# Altium schematic sheet greenhouse_esp32_V0.1.schdoc
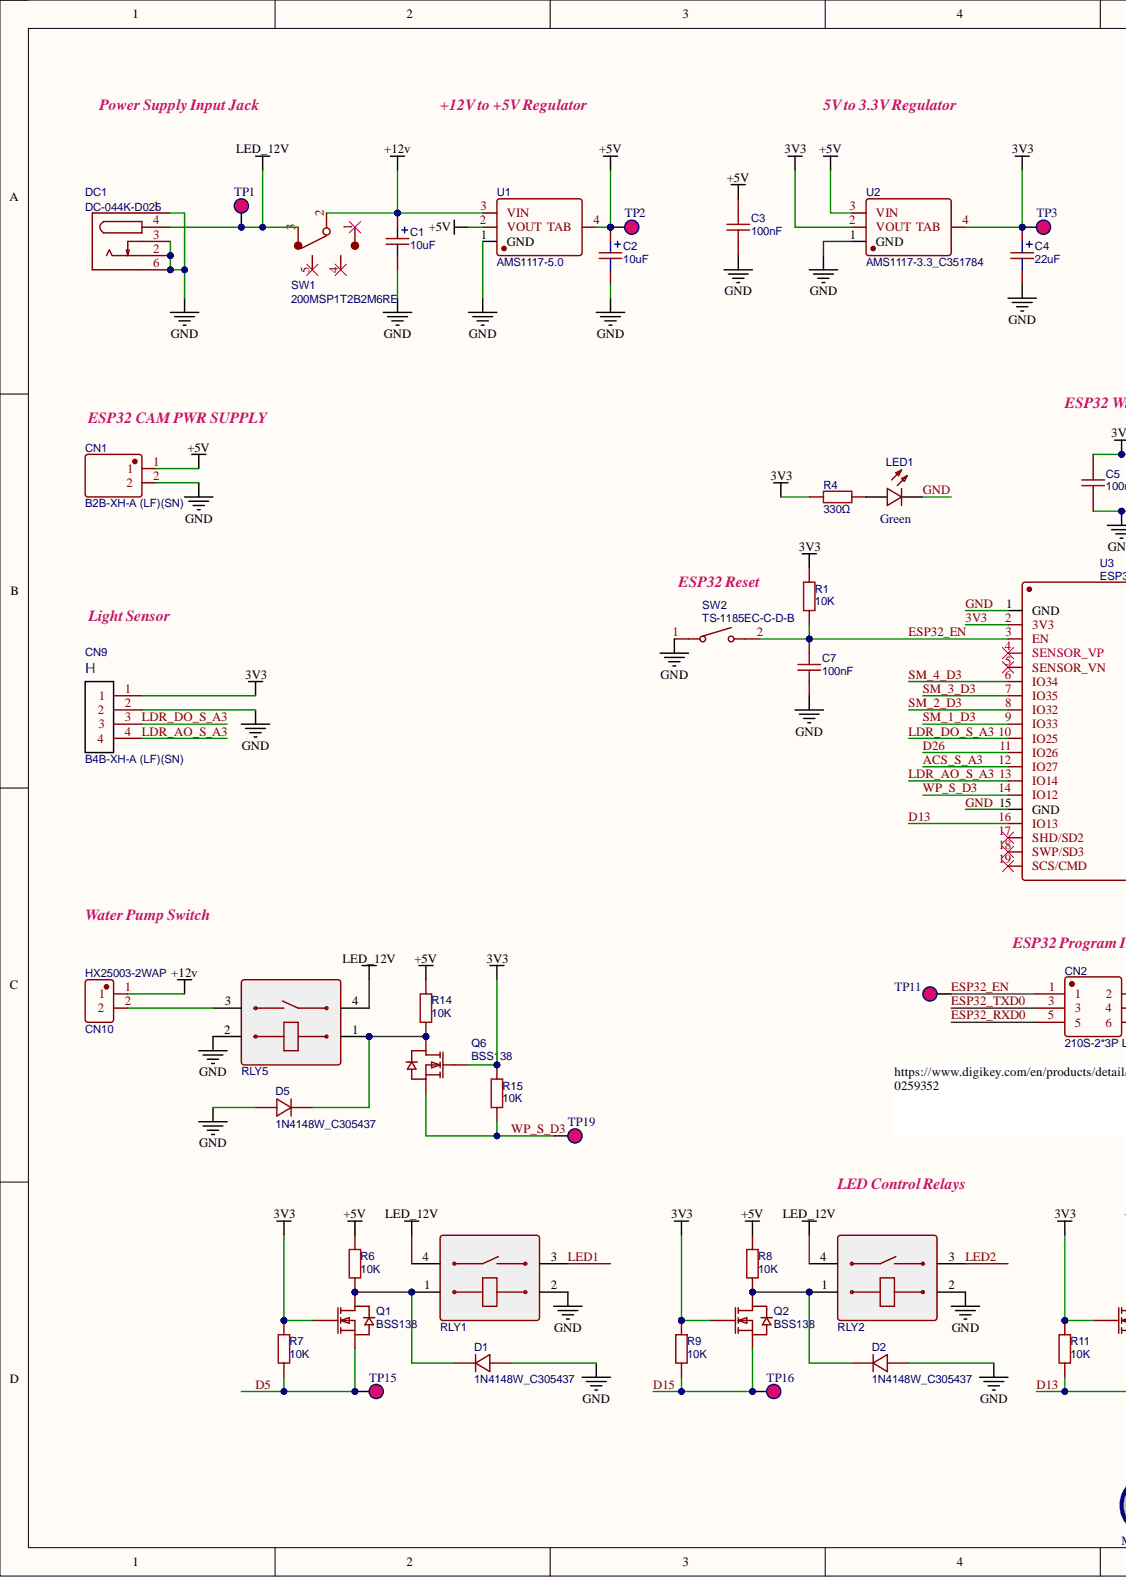
[diagram p0: greenhouse_esp32_V0.1.schdoc, left]
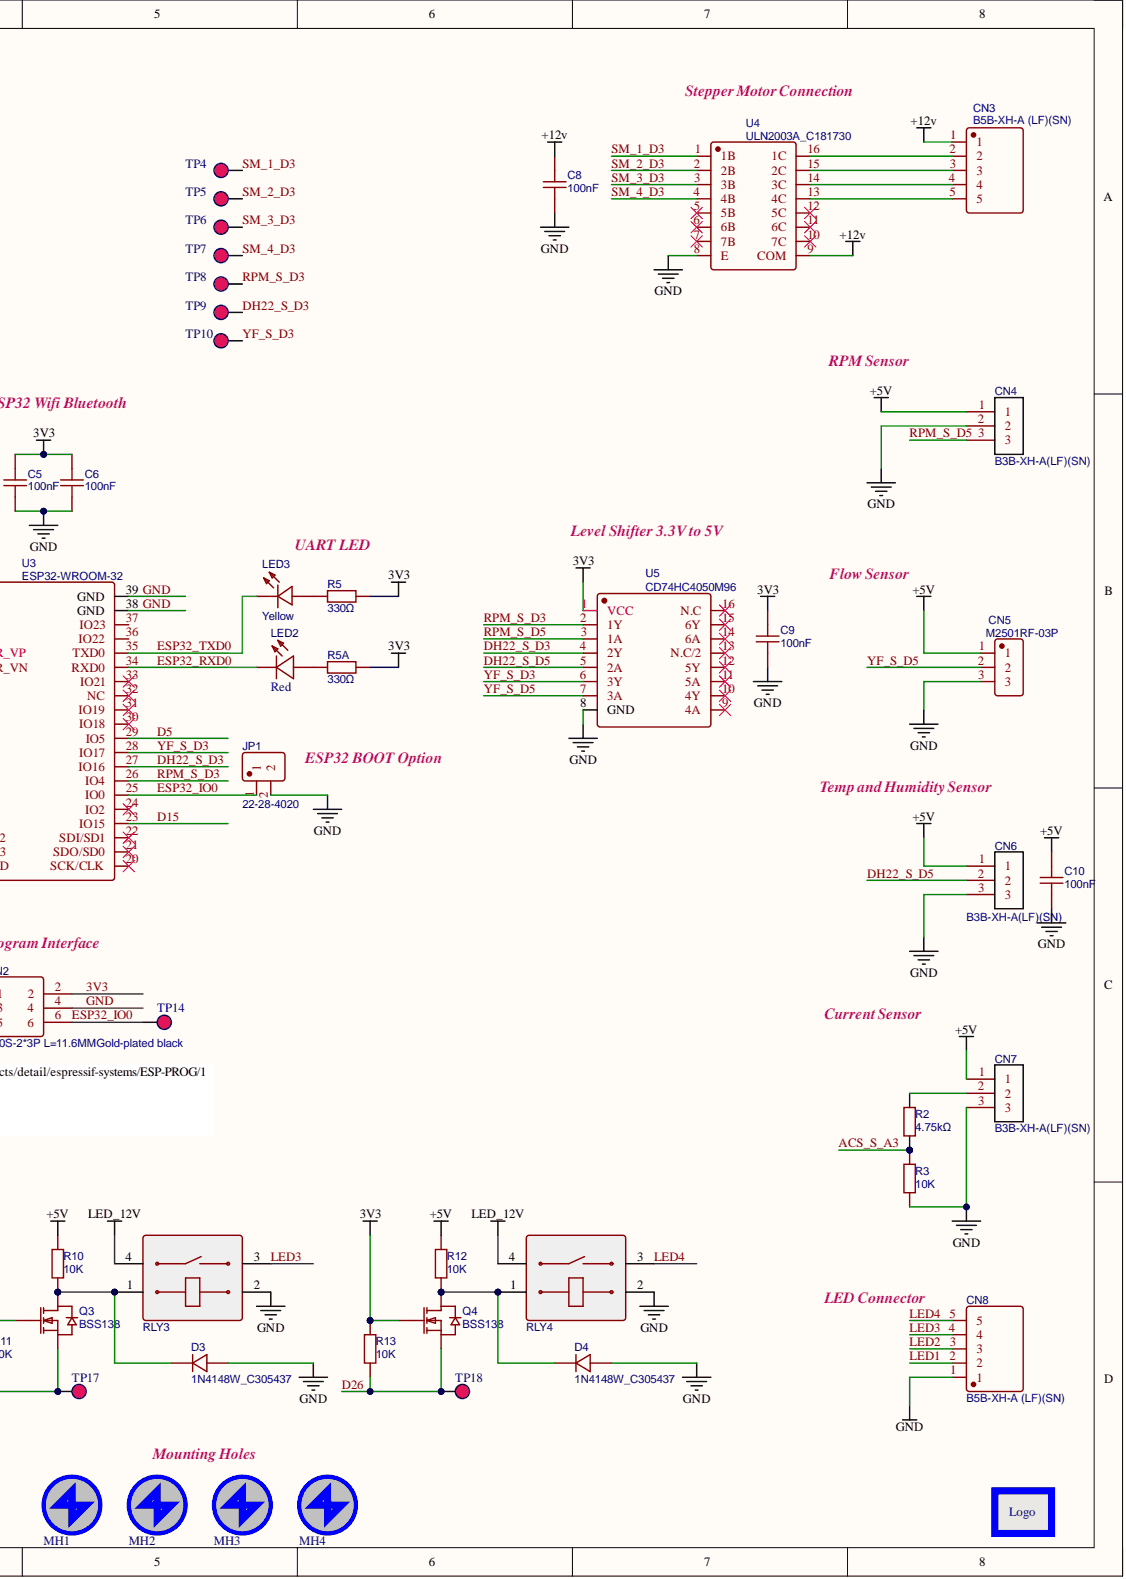
[diagram p1: greenhouse_esp32_V0.1.schdoc, right]

## Parts
C1  10uF  [CAPPM3528X210N]
C2  10uF  [CAPPM3528X210N]
C3  100nF  [C0805]
C4  22uF  [CAPPM3528X210N]
C5  100nF  [C0805]
C6  100nF  [C0805]
C7  100nF  [C0805]
C8  100nF  [C0805]
C9  100nF  [C0805]
C10  100nF  [C0805]
CN1  B2B-XH-A (LF)(SN)  [CONN-TH_B2B-XH-A-LF-SN]
CN2  210S-2*3P L=11.6MMGold-plated black  [HDR-TH_6P-P2.54-V-M-R2-C3-S2.54-1]
CN3  B5B-XH-A (LF)(SN)  [CONN-TH_B5B-XH-A-LF-SN]
CN4  B3B-XH-A(LF)(SN)  [CONN-TH_3P-P2.50_B3B-XH-A-LF-SN]
CN5  M2501RF-03P  [CONN-TH_M2501RF-03P]
CN6  B3B-XH-A(LF)(SN)  [CONN-TH_3P-P2.50_B3B-XH-A-LF-SN]
CN7  B3B-XH-A(LF)(SN)  [CONN-TH_3P-P2.50_B3B-XH-A-LF-SN]
CN8  B5B-XH-A (LF)(SN)  [CONN-TH_B5B-XH-A-LF-SN]
CN9  B4B-XH-A (LF)(SN)  [CONN-TH_B4B-XH-A-LF-SN]
CN10  HX25003-2WAP  [CONN-TH_2P-P2.50_HX25003-2WAP]
D1  1N4148W_C305437  [SOD-123F_L2.7-W1.7-LS3.7-RD-1]
D2  1N4148W_C305437  [SOD-123F_L2.7-W1.7-LS3.7-RD-1]
D3  1N4148W_C305437  [SOD-123F_L2.7-W1.7-LS3.7-RD-1]
D4  1N4148W_C305437  [SOD-123F_L2.7-W1.7-LS3.7-RD-1]
D5  1N4148W_C305437  [SOD-123F_L2.7-W1.7-LS3.7-RD-1]
DC1  DC-044K-D025  [DC-IN-TH_DC-044K-D025]
G1  Logo  [logo]  (no BOM)
JP1  22-28-4020  [HDR-TH_2P-P2.54-V-M-1]
LED1  value Green  [LED0603-RD]  (hidden comment: FC-D1608HYK-588J)
LED2  value Red  [LED0603-RD]  (hidden comment: FC-1608HRK-620H)
LED3  Yellow  [LED0603-RD]
MH1  Mounting Hole 3.4mm  [mounting_hole_3.4mm]  (value not drawn)  (no BOM)
MH2  Mounting Hole 3.4mm  [mounting_hole_3.4mm]  (value not drawn)  (no BOM)
MH3  Mounting Hole 3.4mm  [mounting_hole_3.4mm]  (value not drawn)  (no BOM)
MH4  Mounting Hole 3.4mm  [mounting_hole_3.4mm]  (value not drawn)  (no BOM)
Q1  BSS138  [SOT-23-3_L2.9-W1.3-P1.90-LS2.4-BR]
Q2  BSS138  [SOT-23-3_L2.9-W1.3-P1.90-LS2.4-BR]
Q3  BSS138  [SOT-23-3_L2.9-W1.3-P1.90-LS2.4-BR]
Q4  BSS138  [SOT-23-3_L2.9-W1.3-P1.90-LS2.4-BR]
Q6  BSS138  [SOT-23-3_L2.9-W1.3-P1.90-LS2.4-BR]
R1  10K  [RESC1608X55N]
R2  4.75kΩ  [RESC1608X55N]
R3  10K  [RESC1608X55N]
R4  330Ω  [R0603]
R5  330Ω  [R0603]
R5A  330Ω  [R0603]
R6  10K  [RESC1608X55N]
R7  10K  [RESC1608X55N]
R8  10K  [RESC1608X55N]
R9  10K  [RESC1608X55N]
R10  10K  [RESC1608X55N]
R11  10K  [RESC1608X55N]
R12  10K  [RESC1608X55N]
R13  10K  [RESC1608X55N]
R14  10K  [RESC1608X55N]
R15  10K  [RESC1608X55N]
RLY1  HF32F-G/005-HS  [RELAY-TH_HF32F-X-HXXXX-XXX]  (value not drawn)
RLY2  HF32F-G/005-HS  [RELAY-TH_HF32F-X-HXXXX-XXX]  (value not drawn)
RLY3  HF32F-G/005-HS  [RELAY-TH_HF32F-X-HXXXX-XXX]  (value not drawn)
RLY4  HF32F-G/005-HS  [RELAY-TH_HF32F-X-HXXXX-XXX]  (value not drawn)
RLY5  HF32F-G/005-HS  [RELAY-TH_HF32F-X-HXXXX-XXX]  (value not drawn)
SW1  200MSP1T2B2M6RE  [SW-TH_3P-P2.54_L9.1-W8.1]
SW2  TS-1185EC-C-D-B  [SW-SMD_4P-L3.7-W3.0-P1.50-LS4.7]
TP1  (no value)  [SMD_TP]  (no BOM)
TP2  (no value)  [SMD_TP]  (no BOM)
TP3  (no value)  [SMD_TP]  (no BOM)
TP4  (no value)  [SMD_TP]  (no BOM)
TP5  (no value)  [SMD_TP]  (no BOM)
TP6  (no value)  [SMD_TP]  (no BOM)
TP7  (no value)  [SMD_TP]  (no BOM)
TP8  (no value)  [SMD_TP]  (no BOM)
TP9  (no value)  [SMD_TP]  (no BOM)
TP10  (no value)  [SMD_TP]  (no BOM)
TP11  (no value)  [SMD_TP]  (no BOM)
TP14  (no value)  [SMD_TP]  (no BOM)
TP15  (no value)  [SMD_TP]  (no BOM)
TP16  (no value)  [SMD_TP]  (no BOM)
TP17  (no value)  [SMD_TP]  (no BOM)
TP18  (no value)  [SMD_TP]  (no BOM)
TP19  (no value)  [SMD_TP]  (no BOM)
U1  AMS1117-5.0  [SOT-223-3_L6.5-W3.4-P2.30-LS7.0-BR]
U2  AMS1117-3.3_C351784  [SOT-223-3_L6.5-W3.4-P2.30-LS7.0-BR]
U3  ESP32-WROOM-32  [WIFIM-SMD_ESP-32S]
U4  ULN2003A_C181730  [SOP-16_L10.0-W3.9-P1.27-LS6.0-BL]
U5  CD74HC4050M96  [SOIC-16_L9.9-W3.9-P1.27-LS6.0-BL]

## Nets
+5V: C2.1 C3.2 C10.2 CN1.1 CN4.1 CN5.1 CN6.1 CN7.1 R6.1 R8.1 R10.1 R12.1 R14.1 TP2.1 U1.2(VOUT) U1.4(TAB) U2.3(VIN)
+12v: C1.1 C8.2 CN3.1 CN10.1 SW1.2 U1.3(VIN) U4.9(COM)
LED_12V: DC1.4 RLY1.4 RLY2.4 RLY3.4 RLY4.4 RLY5.4 SW1.3 TP1.1
3V3: C4.1 C5.2 C6.2 C9.2 CN2.2 CN9.1 Q1.1 Q2.1 Q3.1 Q4.1 Q6.1 R1.2 R4.1 R5.1 R5A.1 R7.1 R9.1 R11.1 R13.1 R15.1 TP3.1 U2.2(VOUT) U2.4(TAB) U3.2(3V3) U5.1(VCC)
ACS_S_A3: R2.1 R3.2 U3.12(IO27)
D5: Q1.2 R7.2 TP15.1 U3.29(IO5)
D13: Q3.2 R11.2 TP17.1 U3.16(IO13)
D15: Q2.2 R9.2 TP16.1 U3.23(IO15)
D26: Q4.2 R13.2 TP18.1 U3.11(IO26)
DH22_S_D3: TP9.1 U3.27(IO16) U5.4(2Y)
DH22_S_D5: CN6.2 U5.5(2A)
ESP32_EN: C7.2 CN2.1 R1.1 SW2.2 TP11.1 U3.3(EN)
ESP32_IO0: CN2.6 JP1.1 TP14.1 U3.25(IO0)
ESP32_RXD0: CN2.5 LED2.1 U3.34(RXD0)
ESP32_TXD0: CN2.3 LED3.1 U3.35(TXD0)
GND: C1.2 C2.2 C3.1 C4.2 C5.1 C6.1 C7.1 C8.1 C9.1 C10.1 CN1.2 CN2.4 CN4.2 CN5.3 CN6.3 CN7.3 CN8.1 CN9.2 D1.2 D2.2 D3.2 D4.2 D5.2 DC1.1 DC1.2 DC1.3 DC1.6 JP1.2 LED1.1 R3.1 RLY1.2 RLY2.2 RLY3.2 RLY4.2 RLY5.2 SW2.1 U1.1(GND) U2.1(GND) U3.1(GND) U3.15(GND) U3.38(GND) U3.39(GND) U4.8(E) U5.8(GND)
LDR_AO_S_A3: CN9.4 U3.13(IO14)
LDR_DO_S_A3: CN9.3 U3.10(IO25)
LED1: CN8.2 RLY1.3
LED2: CN8.3 RLY2.3
LED3: CN8.4 RLY3.3
LED4: CN8.5 RLY4.3
RPM_S_D3: TP8.1 U3.26(IO4) U5.2(1Y)
RPM_S_D5: CN4.3 U5.3(1A)
SM_1_D3: TP4.1 U3.9(IO33) U4.1(1B)
SM_2_D3: TP5.1 U3.8(IO32) U4.2(2B)
SM_3_D3: TP6.1 U3.7(IO35) U4.3(3B)
SM_4_D3: TP7.1 U3.6(IO34) U4.4(4B)
WP_S_D3: Q6.2 R15.2 TP19.1 U3.14(IO12)
YF_S_D3: TP10.1 U3.28(IO17) U5.6(3Y)
YF_S_D5: CN5.2 U5.7(3A)
NetCN3_2: CN3.2 U4.16(1C)
NetCN3_3: CN3.3 U4.15(2C)
NetCN3_4: CN3.4 U4.14(3C)
NetCN3_5: CN3.5 U4.13(4C)
NetCN7_2: CN7.2 R2.2
NetCN10_2: CN10.2 RLY5.3
NetD1_1: D1.1 Q1.3 R6.2 RLY1.1
NetD2_1: D2.1 Q2.3 R8.2 RLY2.1
NetD3_1: D3.1 Q3.3 R10.2 RLY3.1
NetD4_1: D4.1 Q4.3 R12.2 RLY4.1
NetD5_1: D5.1 Q6.3 R14.2 RLY5.1
NetLED1_2: LED1.2 R4.2
NetLED2_2: LED2.2 R5A.2
NetLED3_2: LED3.2 R5.2

Not connected: SW1 1 4 5; U3 4(SENSOR_VP) 5(SENSOR_VN) 17(SHD/SD2) 18(SWP/SD3) 19(SCS/CMD) 20(SCK/CLK) 21(SDO/SD0) 22(SDI/SD1) 24(IO2) 30(IO18) 31(IO19) 32(NC) 33(IO21) 36(IO22) 37(IO23); U4 5(5B) 6(6B) 7(7B) 10(7C) 11(6C) 12(5C); U5 9(4A) 10(4Y) 11(5A) 12(5Y) 13(N.C/2) 14(6A) 15(6Y) 16(N.C)

## Library data of the parts
C1 C2 = T491B106K025AT: BOM_Manufacturer: KEMET; nameAlias: Capacitance; BOM_Manufacturer Part: T491B106K025AT; BOM_Supplier: LCSC; BOM_Supplier Part: C110053; Component Kind: Standard; spicePre: C; Value: 10uF; Library Name: GreenHouseEsp32_V0.1.SCHLIB
C3 C5-C10 = 100nF: BOM_Manufacturer: 火炬; BOM_Manufacturer Part: C0805B104K500NT; Contributor: LCSC; nameAlias: Capacitance; BOM_JLCPCB Part Class: Extended Part; BOM_Supplier: LCSC; BOM_Supplier Part: C476766; Component Kind: Standard; spicePre: C; spiceSymbolName: C0805B104K500NT; Library Name: GreenHouseEsp32_V0.1.SCHLIB
C4 = T491B226K006AT: 22uF 6.3V -55℃~+125℃ 2Ω@100kHz ±10% CASE-B_3528 Tantalum Capacitors ROHS; BOM_Manufacturer: KEMET; nameAlias: Capacitance; BOM_Manufacturer Part: T491B226K006AT; BOM_Supplier: LCSC; BOM_Supplier Part: C122276; Component Kind: Standard; spicePre: C; Value: 22uF; Library Name: GreenHouseEsp32_V0.1.SCHLIB
CN1 = B2B-XH-A (LF)(SN): BOM_Manufacturer: JST Sales America; Contributor: LCSC; BOM_JLCPCB Part Class: Extended Part; BOM_Manufacturer Part: B2B-XH-A(LF)(SN); BOM_Supplier: LCSC; BOM_Supplier Part: C158012; Component Kind: Standard; spicePre: C; spiceSymbolName: B2B-XH-A (LF)(SN)
CN2 = 210S-2*3P L=11.6MMGold-plated black: BOM_Manufacturer: Ckmtw; Contributor: LCSC; BOM_JLCPCB Part Class: Extended Part; BOM_Manufacturer Part: 210S-2*3P L=11.6MMGold-plated black; BOM_Supplier: LCSC; BOM_Supplier Part: C124384; Component Kind: Standard; Library Name: Sheet_1_2022-03-27.SCHLIB; spicePre: H; spiceSymbolName: 210S-2*3P L=11.6MMGold-plated black
CN3 CN8 = B5B-XH-A (LF)(SN): BOM_Manufacturer: JST Sales America; Contributor: LCSC; BOM_JLCPCB Part Class: Extended Part; BOM_Manufacturer Part: B5B-XH-A (LF)(SN); BOM_Supplier: LCSC; BOM_Supplier Part: C157991; Component Kind: Standard; spicePre: C; spiceSymbolName: B5B-XH-A (LF)(SN)
CN4 CN6 CN7 = B3B-XH-A(LF)(SN): BOM_Manufacturer: JST Sales America; Contributor: LCSC; BOM_JLCPCB Part Class: Extended Part; BOM_Manufacturer Part: B3B-XH-A(LF)(SN); BOM_Supplier: LCSC; BOM_Supplier Part: C144394; Component Kind: Standard; spicePre: U; spiceSymbolName: B3B-XH-A(LF)(SN)
CN5 = M2501RF-03P: BOM_Manufacturer: XFCN; Contributor: LCSC; BOM_JLCPCB Part Class: Extended Part; BOM_Manufacturer Part: M2501RF-03P; BOM_Supplier: LCSC; BOM_Supplier Part: C492479; Component Kind: Standard; spicePre: C; spiceSymbolName: M2501RF-03P
CN9 = B4B-XH-A (LF)(SN): Contributor: hctan22; BOM_Manufacturer Part: B4B-XH-A (LF)(SN); BOM_Supplier: LCSC; BOM_Supplier Part: C144395; Component Kind: Standard; spicePre: U; spiceSymbolName: B4B-XH-A (LF)(SN)
CN10 = HX25003-2WAP: BOM_Manufacturer: HX(Zhejiang Yueqing Hongxing Elec); Contributor: LCSC; BOM_JLCPCB Part Class: Extended Part; BOM_Manufacturer Part: HX25003-2WAP; BOM_Supplier: LCSC; BOM_Supplier Part: C442326; Component Kind: Standard; spicePre: C; spiceSymbolName: HX25003-2WAP
D1-D5 = 1N4148W_C305437: BOM_Manufacturer: BLUE ROCKET; Contributor: LCSC; BOM_JLCPCB Part Class: Extended Part; BOM_Manufacturer Part: 1N4148W; BOM_Supplier: LCSC; BOM_Supplier Part: C305437; Component Kind: Standard; Library Name: Sheet_1_2022-03-27.SCHLIB; spicePre: D; spiceSymbolName: 1N4148W_C305437
DC1 = DC-044K-D025: BOM_Manufacturer: G-Switch(品赞); Contributor: LCSC; BOM_JLCPCB Part Class: Extended Part; BOM_Manufacturer Part: DC-044K-D025; BOM_Supplier: LCSC; BOM_Supplier Part: C963204; Component Kind: Standard; spicePre: D; spiceSymbolName: DC-044K-D025
JP1 = 22-28-4020: BOM_Manufacturer: MOLEX; Contributor: LCSC; BOM_JLCPCB Part Class: Extended Part; BOM_Manufacturer Part: 22-28-4020; BOM_Supplier: LCSC; BOM_Supplier Part: C234182; Component Kind: Standard; Library Name: Sheet_1_2022-03-27.SCHLIB; spicePre: C; spiceSymbolName: 22-28-4020
LED1 = FC-D1608HYK-588J: Green/Yellow-Green 0603 Light Emitting Diodes (LED) ROHS; BOM_Manufacturer: NATIONSTAR; BOM_Manufacturer Part: NCD060C3; BOM_JLCPCB Part Class: Extended Part; BOM_Supplier: LCSC; BOM_Supplier Part: C89809; Component Kind: Standard; Library Name: Sheet_1_2022-03-27.SCHLIB; spicePre: L; spiceSymbolName: FC-D1608HYK-588J
LED2 = FC-1608HRK-620H: Red 0603 Light Emitting Diodes (LED) ROHS; BOM_Manufacturer: NATIONSTAR; BOM_JLCPCB Part Class: Extended Part; BOM_Manufacturer Part: FC-1608HRK-620H; BOM_Supplier: LCSC; BOM_Supplier Part: C90692; Component Kind: Standard; Library Name: Sheet_1_2022-03-27.SCHLIB; spicePre: L; spiceSymbolName: FC-1608HRK-620H
LED3 = FC-1608YXK-585F08: BOM_Manufacturer: NATIONSTAR; Contributor: LCSC; BOM_JLCPCB Part Class: Extended Part; BOM_Manufacturer Part: FC-1608YXK-585F08; BOM_Supplier: LCSC; BOM_Supplier Part: C89811; Component Kind: Standard; Description: Yellow; Library Name: Sheet_1_2022-03-27.SCHLIB; spicePre: L; spiceSymbolName: FC-1608YXK-585F08
MH1-MH4 = Mounting Hole 3.4mm: M3 screw; Library Name: clairify iot module V0.1 12th march, 2022.SCHLIB
Q1-Q4 Q6 = BSS138: BOM_Manufacturer: CJ; BOM_JLCPCB Part Class: Extended Part; BOM_Manufacturer Part: BSS138; BOM_Supplier: LCSC; BOM_Supplier Part: C78284; Component Kind: Standard; spicePre: Q; spiceSymbolName: BSS138
R1 R3 R6-R15 = 10K: BOM_Manufacturer: YAGEO; BOM_Manufacturer Part: RC0603JR-0710KL; Contributor: LCSC; nameAlias: Resistance (Ohms); Resistance: 10kΩ; BOM_JLCPCB Part Class: Extended Part; BOM_Supplier: LCSC; BOM_Supplier Part: C99198; Component Kind: Standard; spicePre: R; spiceSymbolName: RC0603JR-0710KL; Library Name: GreenHouseEsp32_V0.1.SCHLIB
R2 = 4.75kΩ: ±1% ±100ppm/℃ 4.75kΩ 1/10W Thick Film Resistors 75V -55℃~+155℃ 0603 Chip Resistor - Surface Mount ROHS; BOM_Manufacturer: RALEC; BOM_Manufacturer Part: RTT034751FTP; nameAlias: Resistance; Resistance (Ohms): 4.75K; BOM_JLCPCB Part Class: Extended Part; BOM_Supplier: LCSC; BOM_Supplier Part: C103666; Component Kind: Standard; spicePre: R; Library Name: GreenHouseEsp32_V0.1.SCHLIB
R4 R5 R5A = 330Ω: BOM_Manufacturer: Tyohm; BOM_Manufacturer Part: RMC06033305%N; Contributor: LCSC; nameAlias: Resistance; Resistance (Ohms): 330; BOM_JLCPCB Part Class: Extended Part; BOM_Supplier: LCSC; BOM_Supplier Part: C269524; Component Kind: Standard; Library Name: Sheet_1_2022-03-27.SCHLIB; spicePre: R; spiceSymbolName: RMC06033305%N
RLY1-RLY5 = HF32F-G/005-HS: BOM_Manufacturer: HF; BOM_JLCPCB Part Class: Extended Part; BOM_Manufacturer Part: HF32F-G/005-HS; BOM_Supplier: LCSC; BOM_Supplier Part: C74541; Component Kind: Standard; spicePre: R; spiceSymbolName: HF32F-G/005-HS
SW1 = 200MSP1T2B2M6RE: BOM_Manufacturer: E-Switch; Contributor: LCSC; BOM_JLCPCB Part Class: Extended Part; BOM_Manufacturer Part: 200MSP1T2B2M6RE; BOM_Supplier: LCSC; BOM_Supplier Part: C1788489; Component Kind: Standard; spicePre: S; spiceSymbolName: 200MSP1T2B2M6RE
SW2 = TS-1185EC-C-D-B: BOM_Manufacturer: XKB Enterprise; Contributor: LCSC; BOM_JLCPCB Part Class: Extended Part; BOM_Manufacturer Part: TS-1185EC-C-D-B; BOM_Supplier: LCSC; BOM_Supplier Part: C318893; Component Kind: Standard; spicePre: S; spiceSymbolName: TS-1185EC-C-D-B
TP1-TP11 TP14-TP19 = SMD_TP: Test point; Geometry.Height: 0.9mm; Arrow Part Number: C0603C475K8PAC7411; Datasheet Link: https://componentsearchengine.com/Datasheets/2/C0603C105K4RACTU.pdf; Library Name: C0603C105K4RACTU.SchLib
U1 = AMS1117-5.0: 1.4A 1.5V@(1A) Fixed 5V~5V Positive 1 18V SOT-223 Linear Voltage Regulators (LDO) ROHS; BOM_Manufacturer: SIKOR; Contributor: LCSC; BOM_Manufacturer Part: AMS1117-5.0; BOM_Supplier: LCSC; BOM_Supplier Part: C473812; Component Kind: Standard; spicePre: U; spiceSymbolName: AMS1117-5.0; Library Name: GreenHouseEsp32_V0.1.SCHLIB
U2 = AMS1117-3.3_C351784: BOM_Manufacturer: PUOLOP; Contributor: LCSC; BOM_JLCPCB Part Class: Extended Part; BOM_Manufacturer Part: AMS1117-3.3; BOM_Supplier: LCSC; BOM_Supplier Part: C351784; Component Kind: Standard; spicePre: U; spiceSymbolName: AMS1117-3.3_C351784
U3 = ESP32-WROOM-32: BOM_Manufacturer: Espressif Systems; Contributor: LCSC; BOM_JLCPCB Part Class: Extended Part; BOM_Manufacturer Part: ESP32-WROOM-32; BOM_Supplier: LCSC; BOM_Supplier Part: C82899; Component Kind: Standard; spicePre: U; spiceSymbolName: ESP32-WROOM-32
U4 = ULN2003A_C181730: BOM_Manufacturer: Sinotech Mixic Elec; Contributor: LCSC; BOM_JLCPCB Part Class: Extended Part; BOM_Manufacturer Part: ULN2003A; BOM_Supplier: LCSC; BOM_Supplier Part: C181730; Component Kind: Standard; spicePre: U; spiceSymbolName: ULN2003A_C181730
U5 = CD74HC4050M96: BOM_Manufacturer: TI; BOM_JLCPCB Part Class: Extended Part; BOM_Manufacturer Part: CD74HC4050M96; BOM_Supplier: LCSC; BOM_Supplier Part: C131932; Component Kind: Standard; spicePre: U; spiceSymbolName: CD74HC4050M96
G1 = Logo: Library Name: GreenHouseEsp32_V0.1_1.SCHLIB
